# Altium schematic sheet NRFDK_Shield.SchDoc(NRFDK_Shield)
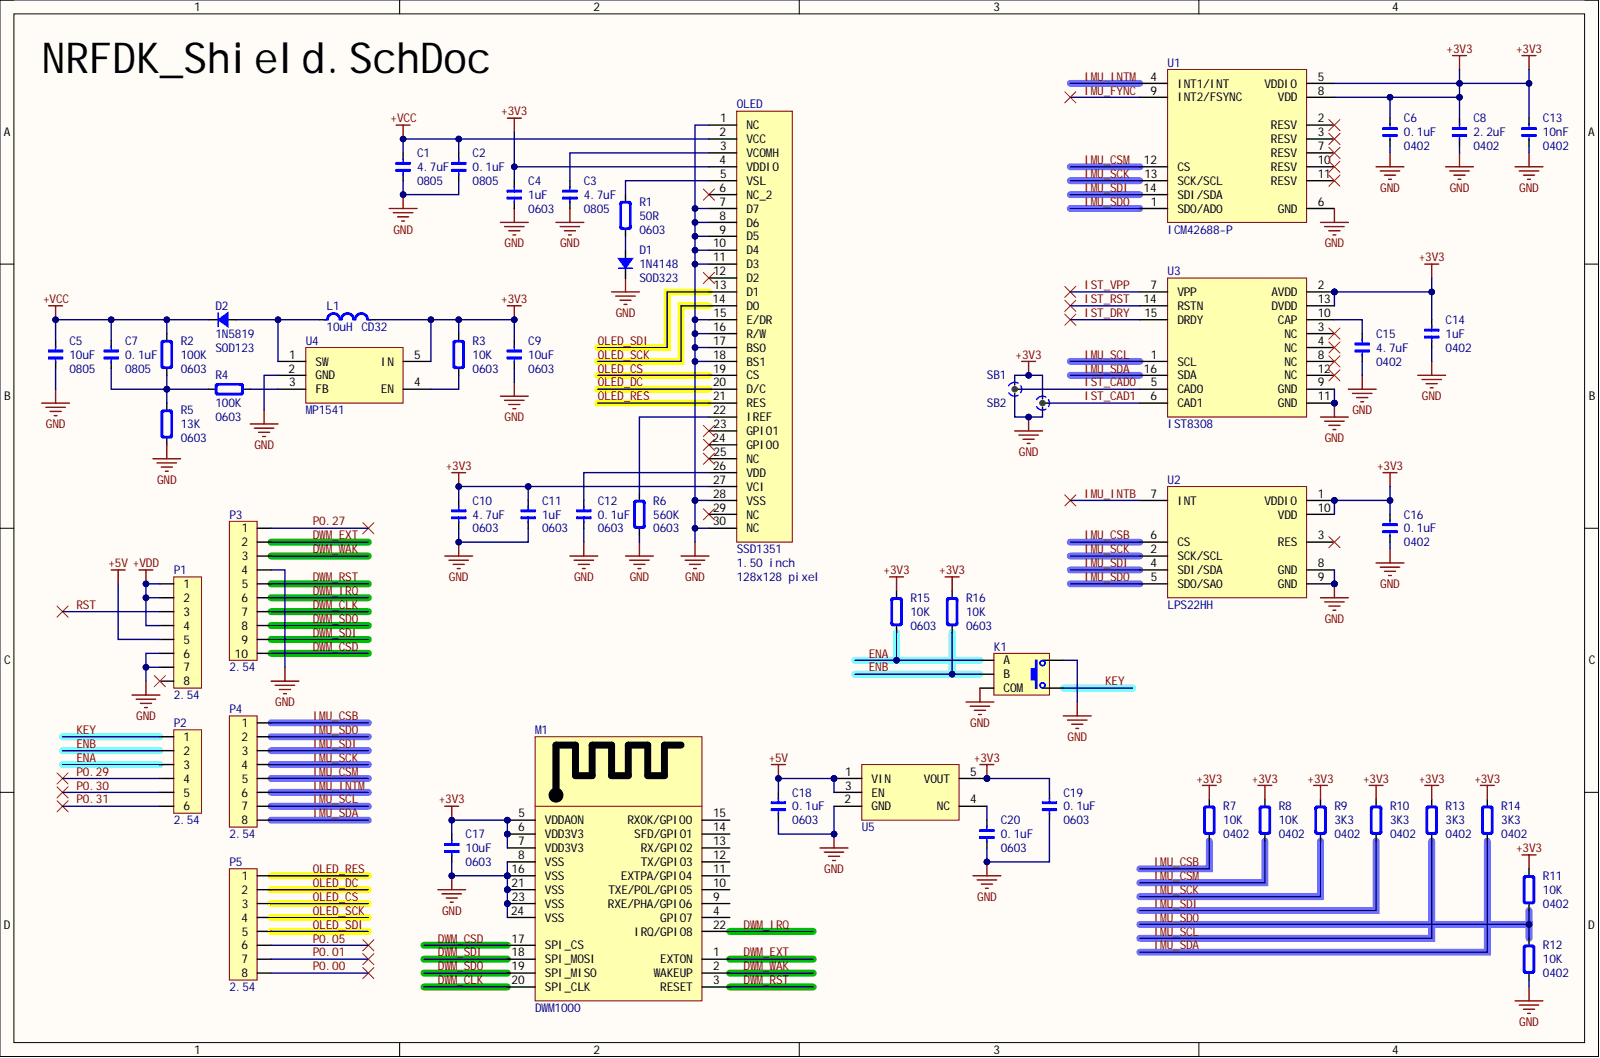
[diagram p0: NRFDK_Shield.SchDoc]

## Parts
C1  C0805  value 4.7uF  Type: 0805  [CAP_0805]
C2  value 0.1uF  Type: 0805  [CAP_0805]  (hidden comment: C0805)
C3  value 4.7uF  Type: 0805  [CAP_0805]  (hidden comment: C0805)
C4  value 1uF  Type: 0603  [CAP_0603]  (hidden comment: C0603)
C5  value 10uF  Type: 0805  [CAP_0805]  (hidden comment: C0805)
C6  value 0.1uF  Type: 0402  [CAP_0402]  (hidden comment: C0402)
C7  value 0.1uF  Type: 0805  [CAP_0805]  (hidden comment: C0805)
C8  value 2.2uF  Type: 0402  [CAP_0402]  (hidden comment: C0402)
C9  value 10uF  Type: 0603  [CAP_0603]  (hidden comment: C0603)
C10  value 4.7uF  Type: 0603  [CAP_0603]  (hidden comment: C0603)
C11  value 1uF  Type: 0603  [CAP_0603]  (hidden comment: C0603)
C12  value 0.1uF  Type: 0603  [CAP_0603]  (hidden comment: C0603)
C13  value 10nF  Type: 0402  [CAP_0402]  (hidden comment: C0402)
C14  value 1uF  Type: 0402  [CAP_0402]  (hidden comment: C0402)
C15  value 4.7uF  Type: 0402  [CAP_0402]  (hidden comment: C0402)
C16  value 0.1uF  Type: 0402  [CAP_0402]  (hidden comment: C0402)
C17  value 10uF  Type: 0603  [CAP_0603]  (hidden comment: C0603)
C18  value 0.1uF  Type: 0603  [CAP_0603]  (hidden comment: C0603)
C19  value 0.1uF  Type: 0603  [CAP_0603]  (hidden comment: C0603)
C20  value 0.1uF  Type: 0603  [CAP_0603]  (hidden comment: C0603)
D1  1N4148  Type: SOD323  [SOD-323]
D2  1N5819  Type: SOD123  [SOD-123]
K1  TACT  [Encoder_Rotary]  (value not drawn)
L1  CD32  value 10uH  [IND_CD32]
M1  DWM1000  [DWM1000]
OLED  value 128x128 pixel  Note: SSD1351  Type: 1.50 inch  [OLED_1.5_128x128_SSD1351]  (hidden comment: OLED_1.5_SSD1351)
P1  value 2.54  [HDR1X8_2.54_180]  (hidden comment: HDR1x8)
P2  value 2.54  [HDR1X6_2.54_180]  (hidden comment: HDR1x6)
P3  value 2.54  [HDR1X10_2.54_180]  (hidden comment: HDR1x10)
P4  value 2.54  [HDR1X8_2.54_180]  (hidden comment: HDR1x8)
P5  value 2.54  [HDR1X8_2.54_180]  (hidden comment: HDR1x8)
R1  value 50R  Type: 0603  [RES_0603]  (hidden comment: R0603)
R2  value 100K  Type: 0603  [RES_0603]  (hidden comment: R0603)
R3  value 10K  Type: 0603  [RES_0603]  (hidden comment: R0603)
R4  value 100K  Type: 0603  [RES_0603]  (hidden comment: R0603)
R5  value 13K  Type: 0603  [RES_0603]  (hidden comment: R0603)
R6  value 560K  Type: 0603  [RES_0603]  (hidden comment: R0603)
R7  value 10K  Type: 0402  [RES_0402]  (hidden comment: R0402)
R8  value 10K  Type: 0402  [RES_0402]  (hidden comment: R0402)
R9  value 3K3  Type: 0402  [RES_0402]  (hidden comment: R0402)
R10  value 3K3  Type: 0402  [RES_0402]  (hidden comment: R0402)
R11  value 10K  Type: 0402  [RES_0402]  (hidden comment: R0402)
R12  value 10K  Type: 0402  [RES_0402]  (hidden comment: R0402)
R13  value 3K3  Type: 0402  [RES_0402]  (hidden comment: R0402)
R14  value 3K3  Type: 0402  [RES_0402]  (hidden comment: R0402)
R15  value 10K  Type: 0603  [RES_0603]  (hidden comment: R0603)
R16  value 10K  Type: 0603  [RES_0603]  (hidden comment: R0603)
SB1  SOLDERBRIDGE  [Solderbridge_3L]  (value not drawn)
SB2  SOLDERBRIDGE  [Solderbridge_3L]  (value not drawn)
U1  ICM42688-P  [ICMx_LGA14_P0.5_2.5x3.0]
U2  LPS22HH  [LPSx_LGA10_P0.5_2.0x2.0]
U3  IST8308  [ISTX_LGA16_P0.5_3.0x3.0]
U4  MP1541  [SOT23-5]
U5  XC6220B331  [SOT23-5]  (value not drawn)

## Nets
+3V3: C4.2 C6.2 C8.2 C9.2 C10.2 C11.2 C13.2 C14.2 C16.2 C17.2 C19.2 L1.2 M1.5(VDDAON) M1.6(VDD3V3) M1.7(VDD3V3) OLED.4(VDDIO) OLED.27(VCI) R3.2 R7.2 R8.2 R9.2 R10.2 R11.2 R13.2 R14.2 R15.2 R16.2 SB1.3 SB2.3 U1.5(VDDIO) U1.8(VDD) U2.1(VDDIO) U2.10(VDD) U3.2(AVDD) U3.13(DVDD) U4.5(IN) U5.5(VOUT)
+5V: C18.2 P1.5 U5.1(VIN) U5.3(EN)
+VCC: C1.2 C2.2 C5.2 C7.2 D2.2 OLED.2(VCC) R2.2
+VDD: P1.1 P1.2 P1.4
GND: C1.1 C2.1 C3.1 C4.1 C5.1 C6.1 C8.1 C9.1 C10.1 C11.1 C12.1 C13.1 C14.1 C15.1 C16.1 C17.1 C18.1 C19.1 C20.1 D1.2 K1.2(COM) K1.5 M1.8(VSS) M1.16(VSS) M1.21(VSS) M1.23(VSS) M1.24(VSS) OLED.1(NC) OLED.7(D7) OLED.8(D6) OLED.9(D5) OLED.10(D4) OLED.11(D3) OLED.15(E/DR) OLED.16(R/W) OLED.17(BS0) OLED.18(BS1) OLED.28(VSS) OLED.30(NC) P1.6 P1.7 P3.4 R5.1 R6.1 R12.1 SB1.1 SB2.1 U1.6(GND) U2.8(GND) U2.9(GND) U3.9(GND) U3.11(GND) U4.2(GND) U5.2(GND)
DWM_CLK: M1.20(SPI_CLK) P3.7
DWM_CSD: M1.17(SPI_CS) P3.10
DWM_EXT: M1.1(EXTON) P3.2
DWM_IRQ: M1.22(IRQ/GPIO8) P3.6
DWM_RST: M1.3(RESET) P3.5
DWM_SDI: M1.18(SPI_MOSI) P3.9
DWM_SDO: M1.19(SPI_MISO) P3.8
DWM_WAK: M1.2(WAKEUP) P3.3
ENA: K1.1(A) P2.3 R15.1
ENB: K1.3(B) P2.2 R16.1
IMU_CSB: P4.1 R7.1 U2.6(CS)
IMU_CSM: P4.5 R8.1 U1.12(CS)
IMU_FYNC: U1.9(INT2/FSYNC)
IMU_INTB: U2.7(INT)
IMU_INTM: P4.6 U1.4(INT1/INT)
IMU_SCK: P4.4 R9.1 U1.13(SCK/SCL) U2.2(SCK/SCL)
IMU_SCL: P4.7 R13.1 U3.1(SCL)
IMU_SDA: P4.8 R14.1 U3.16(SDA)
IMU_SDI: P4.3 R10.1 U1.14(SDI/SDA) U2.4(SDI/SDA)
IMU_SDO: P4.2 R11.1 R12.2 U1.1(SDO/AD0) U2.5(SDO/SA0)
IST_CAD0: SB1.2 U3.5(CAD0)
IST_CAD1: SB2.2 U3.6(CAD1)
IST_DRY: U3.15(DRDY)
IST_RST: U3.14(RSTN)
IST_VPP: U3.7(VPP)
KEY: K1.4 P2.1
OLED_CS: OLED.19(CS) P5.3
OLED_DC: OLED.20(D/C) P5.2
OLED_RES: OLED.21(RES) P5.1
OLED_SCK: OLED.14(D0) P5.4
OLED_SDI: OLED.13(D1) P5.5
P0.00: P5.8
P0.01: P5.7
P0.05: P5.6
P0.27: P3.1
P0.29: P2.4
P0.30: P2.5
P0.31: P2.6
RST: P1.3
NetC3_2: C3.2 OLED.3(VCOMH)
NetC7_1: C7.1 R2.1 R4.2 R5.2
NetC12_2: C12.2 OLED.26(VDD)
NetC15_2: C15.2 U3.10(CAP)
NetC20_2: C20.2 U5.4(NC)
NetD1_1: D1.1 R1.1
NetD2_1: D2.1 L1.1 U4.1(SW)
NetOLED_5: OLED.5(VSL) R1.2
NetOLED_22: OLED.22(IREF) R6.2
NetR3_1: R3.1 U4.4(EN)
NetR4_1: R4.1 U4.3(FB)

Not connected: M1 4(GPIO7) 9(RXE/PHA/GPIO6) 10(TXE/POL/GPIO5) 11(EXTPA/GPIO4) 12(TX/GPIO3) 13(RX/GPIO2) 14(SFD/GPIO1) 15(RXOK/GPIO0); OLED 6(NC_2) 12(D2) 23(GPIO1) 24(GPIO0) 25(NC) 29(NC); P1 8; U1 2(RESV) 3(RESV) 7(RESV) 10(RESV) 11(RESV); U2 3(RES); U3 3(NC) 4(NC) 8(NC) 12(NC)

## Library data of the parts
C1-C3 C5 C7 = Cap_0805: Capacitor; Note: ±10%; Specification: X7R, 16V; Component Kind: Standard; Library Name: SCH_Passive.SchLib
C4 C9-C12 C17-C20 = Cap_0603: Capacitor; Note: ±10%; Specification: X7R, 16V; Component Kind: Standard; Library Name: SCH_Passive.SchLib
C6 C8 C13-C16 = Cap_0402: Capacitor; Note: ±10%; Specification: X7R, 16V; Component Kind: Standard; Library Name: SCH_Passive.SchLib
D1 = Diode_SOD323: Diode; Component Kind: Standard; Library Name: SCH_Active.SchLib; Value: 1N4148
D2 = Diode_SOD123: Diode; Component Kind: Standard; Library Name: SCH_Active.SchLib; Value: 1N5819
K1 = Encoder: Button; Value: 5P; Component Kind: Standard; Library Name: SCH_Switch.SchLib
L1 = Ind_CD32: Power Inductor; Note: ±5%; Specification: High frequency chip inductor ±5%; Component Kind: Standard; Library Name: SCH_Passive.SchLib; Type: CD32
M1 = DWM1000: Ultra-Wideband; Manufacturer: Decawave; Note: DW1000; Specification: 13.00x23.00x1.4 mm; Component Kind: Standard; Datasheet: https://www.decawave.com/wp-content/uploads/2020/09/DWM1000-Datasheet.pdf; Library Name: SCH_Module.SchLib; Link: https://www.decawave.com/product/dwm1000-module/; Type: SMD24 P1.40
OLED = OLED_1.5_SSD1351: OLED; Specification: FPC30; Component Kind: Standard; Library Name: SCH_Module.SchLib
P1 = HDR1x8_2.54: Header; Note: 1x8; Component Kind: Standard; Library Name: SCH_ConnectorHDR.SchLib; Type: DIP
P2 = HDR1x6_2.54: Header; Note: 1x6; Component Kind: Standard; Library Name: SCH_ConnectorHDR.SchLib; Type: DIP
P3 = HDR1x10_2.54T: Header; Note: 1x10; Component Kind: Standard; Library Name: SCH_ConnectorHDR.SchLib; Type: DIP
P4 P5 = HDR1x8_2.54T: Header; Note: 1x8; Component Kind: Standard; Library Name: SCH_ConnectorHDR.SchLib; Type: DIP
R1-R6 R15 R16 = Res_0603: Resistor; Note: ±1%; Specification: 1/10W; Component Kind: Standard; Library Name: SCH_Passive.SchLib
R7-R14 = Res_0402: Resistor; Note: ±1%; Specification: 1/16W; Component Kind: Standard; Library Name: SCH_Passive.SchLib
SB1 SB2 = SOLDERBRIDGE_3P: Solder Birdge; Component Kind: Standard; Library Name: SCH_Module.SchLib
U1 = ICM42688-P: Motion Sensor; Manufacturer: TDK InvenSense; Specification: 2.5x3.0x0.91 mm; Component Kind: Standard; Datasheet: https://invensense.tdk.com/wp-content/uploads/2020/09/DS-000347-ICM-42688-P-v1.3.pdf; Library Name: SCH_Sensor.SchLib; Link: https://invensense.tdk.com/products/motion-tracking/6-axis/icm-42688-p/; Type: LGA14 P0.5
U2 = LPS22HH: Pressure Sensor; Manufacturer: STMicroelectronics; Specification: 2.0x2.0x0.73 mm; Component Kind: Standard; Datasheet: https://www.st.com/resource/en/datasheet/lps22hh.pdf; Library Name: SCH_Sensor.SchLib; Link: https://www.st.com/content/st_com/en/products/mems-and-sensors/pressure-sensors/lps22hh.html; Type: LGA10 P0.5
U3 = IST8308: Magnetometer Sensor; Manufacturer: iSentek; Specification: 3.0x3.0x1.0 mm; Component Kind: Standard; Datasheet: http://www.isentek.com/zhtw/the_product.php?pid=4; Library Name: SCH_Sensor.SchLib; Link: http://www.isentek.com/zhtw/the_product.php?pid=4; Type: LGA16 P0.5
U4 = MP1541: Boost; Manufacturer: Monolithic Power Systems; Note: 1.3 MHz; Specification: 0.47W; Value: 3V ~ 22V; Component Kind: Standard; Datasheet: https://www.monolithicpower.com/en/documentview/productdocument/index/version/2/document_type/Datasheet/lang/en/sku/MP1541/document_id/4071/; Library Name: SCH_Power.SchLib; Link: https://www.monolithicpower.com/en/mp1541.html; Type: SOT23-5
U5 = XC6220x_SOT25: LDO; Manufacturer: Torex Semiconductor; Note: 6.5V; Specification: 1000mA; Value: 3.3V; Component Kind: Standard; Datasheet: https://www.torexsemi.com/file/xc6220/XC6220.pdf; Library Name: SCH_Power.SchLib; Link: https://www.torexsemi.com/products/single-type-voltage-regulators/series/?name=xc6220; Type: SOT23-5
